# Altium schematic sheet Sensor_ref.SchDoc
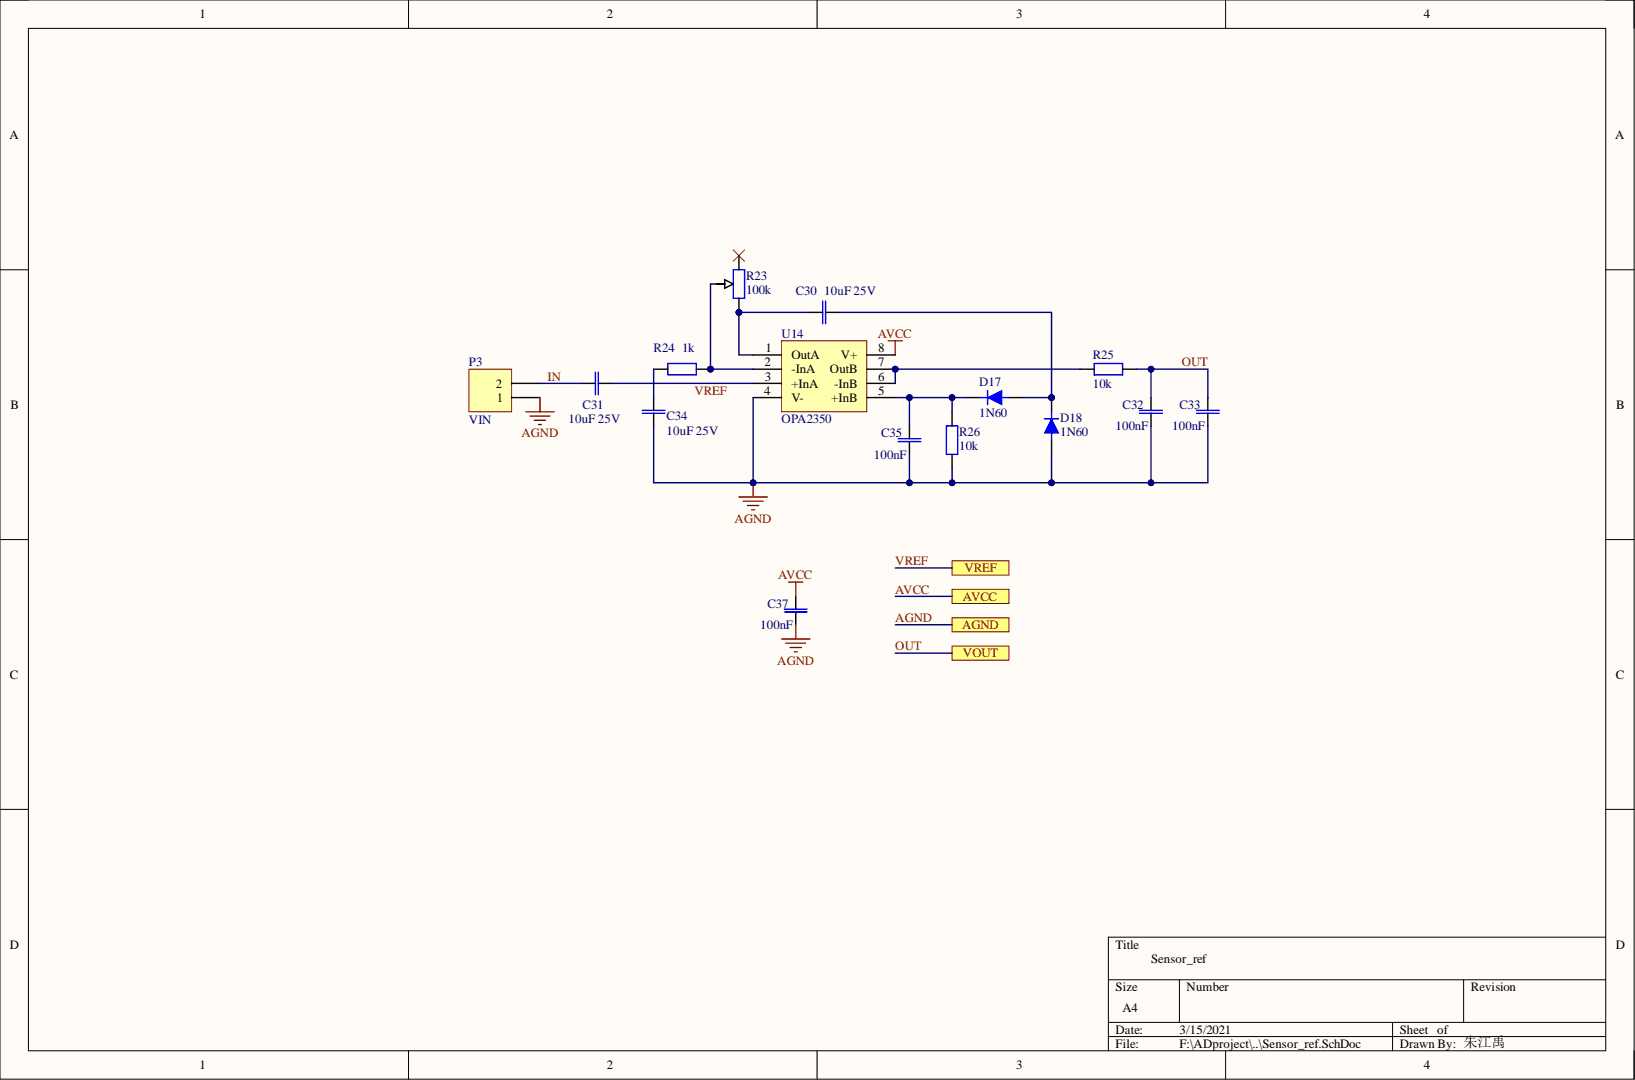
[diagram p0: Sensor_ref.SchDoc]

## Parts
C30  10uF 25V  [1206_c]
C31  10uF 25V  [1206_c]
C32  100nF  [0805_c]
C33  100nF  [0805_c]
C34  10uF 25V  [1206_c]
C35  100nF  [0805_c]
C37  100nF  [0805_c]
D17  1N60  [SOD-123]
D18  1N60  [SOD-123]
P3  VIN  [单排直针 2]
R23  100k  [电位器 直插]
R24  1k  [0805_R]
R25  10k  [0805_R]
R26  10k  [0805_R]
U14  OPA2350  [SOIC-8]

## Ports
AGND, AVCC, VOUT, VREF

## Nets
AGND: C32.2 C33.2 C34.1 C35.2 C37.2 D18.1 P3.1 R26.1 U14.4(V-) ; port AGND
AVCC: C37.1 U14.8(V+) ; port AVCC
IN: C31.1 P3.2
OUT: C32.1 C33.1 R25.2 ; port VOUT
VREF: C31.2 U14.3(+InA) ; port VREF
NetC30_1: C30.1 R23.1 U14.1(OutA)
NetC30_2: C30.2 D17.1 D18.2
NetC34_2: C34.2 R24.1
NetC35_1: C35.1 D17.2 R26.2 U14.5(+InB)
NetR23_2: R23.2 R24.2 U14.2(-InA)
NetR25_1: R25.1 U14.6(-InB) U14.7(OutB)

Not connected: R23 3

## Library data of the parts
C30-C35 C37 = 电容 无极性: Capacitor; Component Kind: Standard; LatestRevisionDate: 17-Jul-2002; LatestRevisionNote: Re-released for DXP Platform.; PackageReference: RAD-0.3; Published: 8-Jun-2000; Signal Integrity: Cap; Simulation: CAP; Library Name: PLZ_main.IntLib; Publisher: Altium Limited
  C30 C31 C34: Value: 10uF 25V
  C32 C33 C35 C37: Value: 100nF
D17 D18 = 二极管 通用: Default Diode; Code_JEDEC: DO-214-AB; Component Kind: Standard; LatestRevisionDate: 17-Jul-2002; LatestRevisionNote: Re-released for DXP Platform.; name: 1N60; PackageReference: SMC; PackageVersion: Sep-1996; Published: 8-Jun-2000; Library Name: PLZ_main.IntLib; Publisher: Altium Limited
P3 = 排针1*2: Header, 2-Pin; Component Kind: Standard; LatestRevisionDate: 17-Jul-2002; LatestRevisionNote: Re-released for DXP Platform.; Signal Integrity: Connector; Library Name: PLZ_con.IntLib; Publisher: Altium Limited
R23 = 电位器: Component Kind: Standard; value: 100k; Library Name: PLZ_main.IntLib
R24-R26 = 电阻 定值: Semiconductor Resistor; Component Kind: Standard; LatestRevisionDate: 17-Jul-2002; LatestRevisionNote: Re-released for DXP Platform.; PackageReference: AXIAL-0.5; Published: 8-Jun-2000; Signal Integrity: Res Semi; Simulation: RES; Library Name: PLZ_main.IntLib; Publisher: Altium Limited
  R24: Value: 1k
  R25 R26: Value: 10k
U14 = OPA2350: Component Kind: Standard; Library Name: PLZ_ics.IntLib
